# Altium schematic sheet ESP32-WROVER-Camera-V1.0.SchDoc
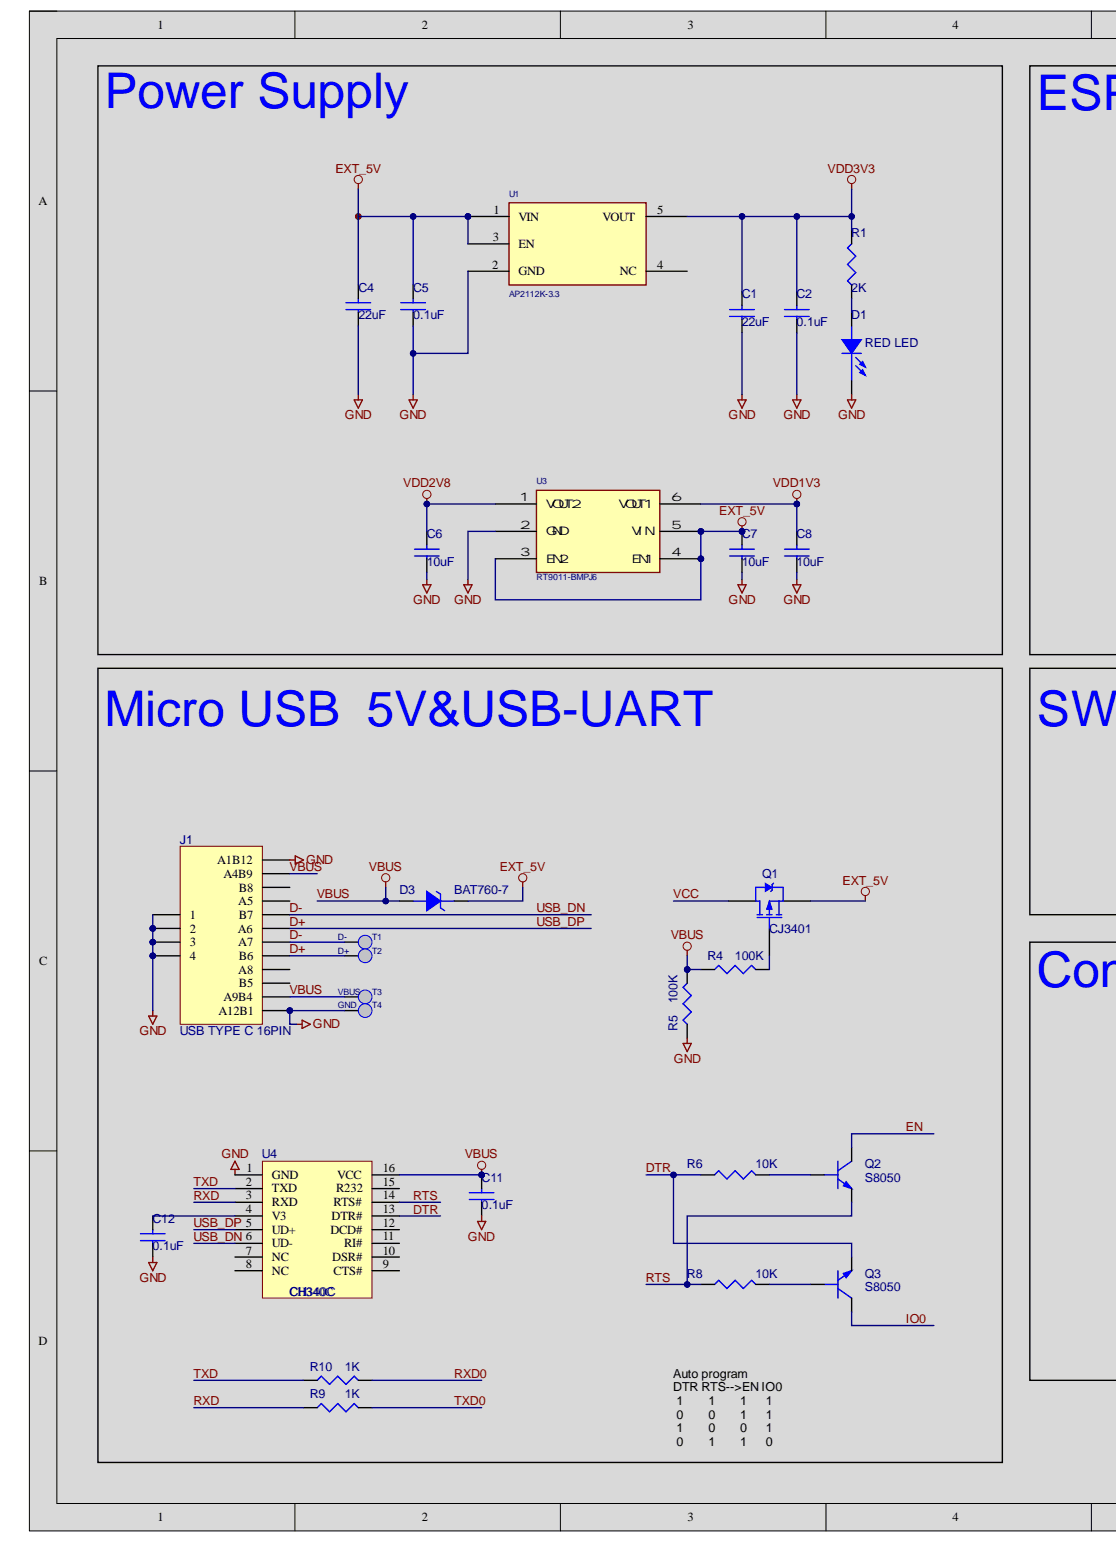
[diagram p0: ESP32-WROVER-Camera-V1.0.SchDoc, left]
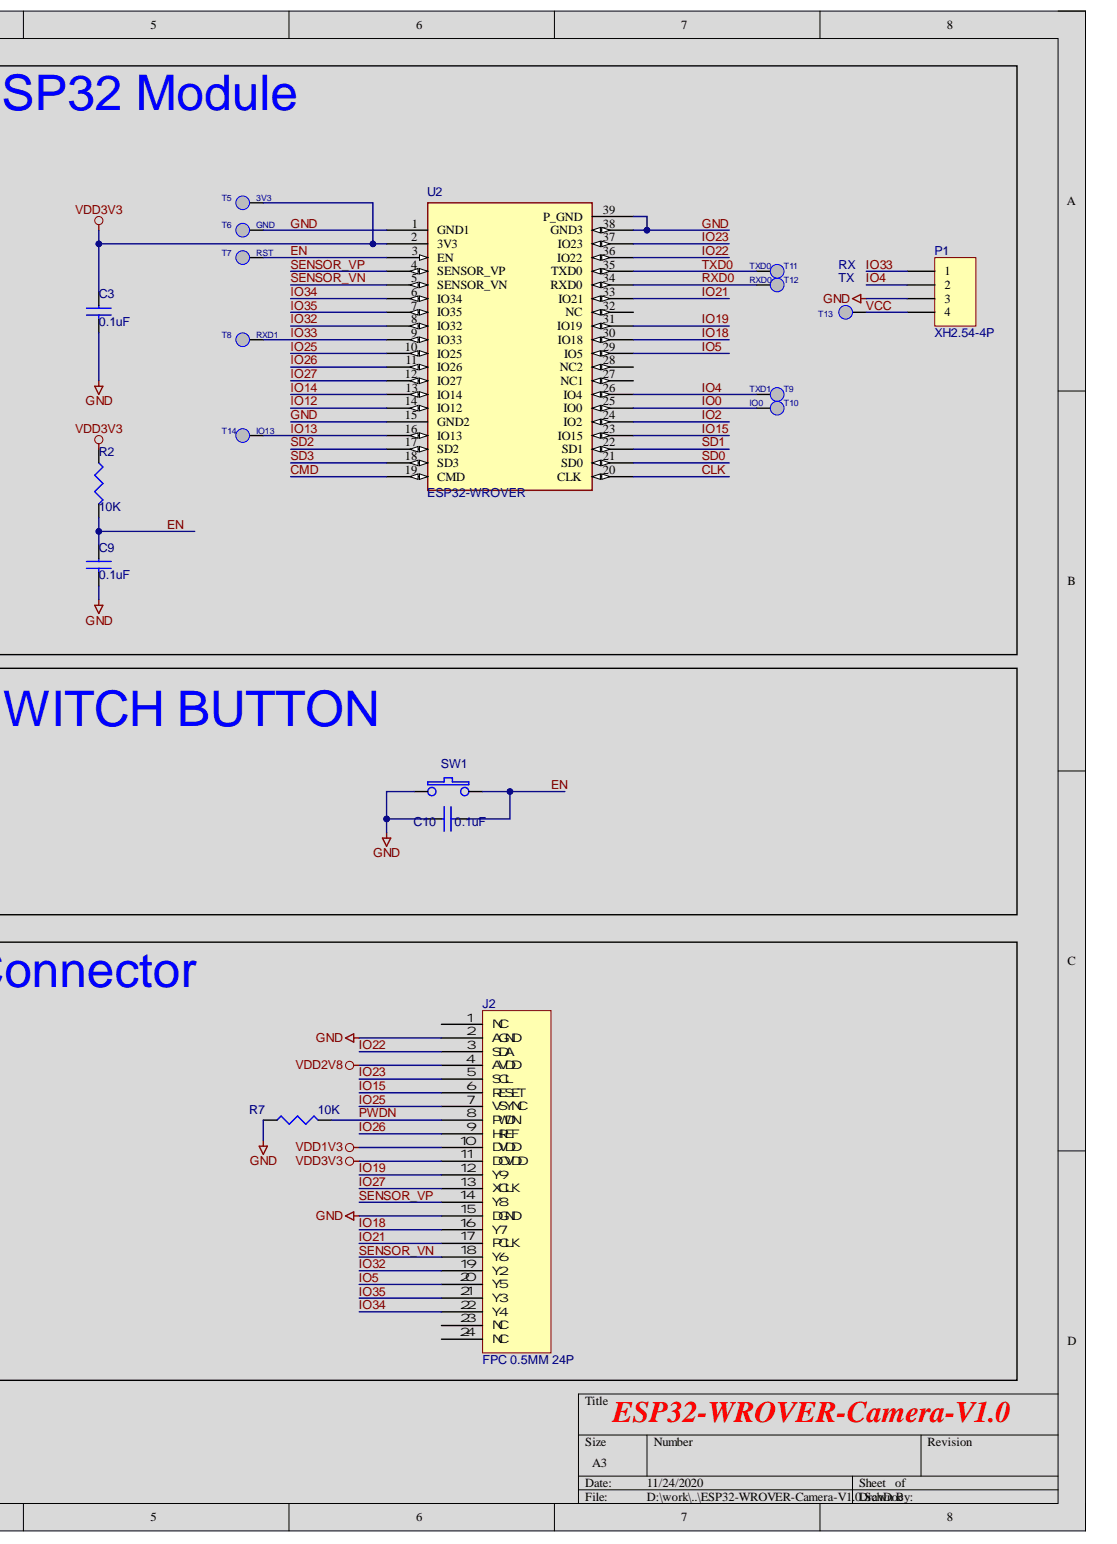
[diagram p1: ESP32-WROVER-Camera-V1.0.SchDoc, right]

## Parts
C1  22uF  [C0603]
C2  0.1uF  [C0603]
C3  0.1uF  [C0603]
C4  22uF  [C0603]
C5  0.1uF  [C0603]
C6  10uF  [C0603]
C7  10uF  [C0603]
C8  10uF  [C0603]
C9  0.1uF  [C0603]
C10  0.1uF  [C0603]
C11  0.1uF  [C0603]
C12  0.1uF  [C0603]
D1  RED LED  [led0603]
D3  BAT760-7  [SOD323]
J1  USB TYPE C 16PIN  [USB-Type-C-16]
J2  FPC 0.5MM 24P  [FPC 0.5MM 24P]
P1  XH2.54-4P  [XH2.54-4AWB]
Q1  CJ3401  [SOT-23-3]
Q2  S8050  [SOT-23-3]
Q3  S8050  [SOT-23-3]
R1  2K  [r0603]
R2  10K  [r0603]
R4  100K  [r0603]
R5  100K  [r0603]
R6  10K  [r0603]
R7  10K  [r0603]
R8  10K  [r0603]
R9  1K  [r0603]
R10  1K  [r0603]
SW1  SW PUSHBUTTON  [SW_3X4X2MM]  (value not drawn)
T1  D-  [PAD1.2mm]
T2  D+  [PAD1.2mm]
T3  VBUS  [PAD1.2mm]
T4  GND  [PAD1.2mm]
T5  3V3  [PAD1.2mm]
T6  GND  [PAD1.2mm]
T7  RST  [PAD1.2mm]
T8  RXD1  [PAD1.2mm]
T9  TXD1  [PAD1.2mm]
T10  IO0  [PAD1.2mm]
T11  TXD0  [PAD1.2mm]
T12  RXD0  [PAD1.2mm]
T13  VCC  [PAD1.2mm]
T14  IO13  [PAD1.2mm]
U1  AP2112K-3.3  [SOT23-5]
U2  ESP32-WROVER  [ESP32_MODULE_2COL]
U3  RT9011-BMPJ6  [SOT23-6]
U4  CH340C  [sop-16]

## Nets
EXT_5V: C4.2 C5.2 C7.2 D3.1 Q1.2 U1.1(VIN) U1.3(EN) U3.3(EN2) U3.4(EN1) U3.5(VIN)
VDD1V3: C8.2 J2.10(DVDD) U3.6(VOUT1)
VDD2V8: C6.2 J2.4(AVDD) U3.1(VOUT2)
VDD3V3: C1.2 C2.2 C3.1 J2.11(DOVDD) R1.1 R2.1 T5.1 U1.5(VOUT) U2.2(3V3)
CLK: U2.20(CLK)
CMD: U2.19(CMD)
D+: J1.A6 J1.B6 T2.1 U4.5(UD+)
D-: J1.A7 J1.B7 T1.1 U4.6(UD-)
DTR: Q3.2 R6.1 U4.13(DTR#)
EN: C9.1 C10.2 Q2.3 R2.2 SW1.2 T7.1 U2.3(EN)
GND: C1.1 C2.1 C3.2 C4.1 C5.1 C6.1 C7.1 C8.1 C9.2 C10.1 C11.1 C12.1 D1.2 J1.1 J1.2 J1.3 J1.4 J1.A1B12 J1.A12B1 J2.2(AGND) J2.15(DGND) P1.3 R5.1 R7.1 SW1.1 T4.1 T6.1 U1.2(GND) U2.1(GND1) U2.15(GND2) U2.38(GND3) U2.39(P_GND) U3.2(GND) U4.1(GND)
IO0: Q3.3 T10.1 U2.25(IO0)
IO2: U2.24(IO2)
IO4: P1.2 T9.1 U2.26(IO4)
IO5: J2.20(Y5) U2.29(IO5)
IO12: U2.14(IO12)
IO13: T14.1 U2.16(IO13)
IO14: U2.13(IO14)
IO15: J2.6(RESET) U2.23(IO15)
IO18: J2.16(Y7) U2.30(IO18)
IO19: J2.12(Y9) U2.31(IO19)
IO21: J2.17(PCLK) U2.33(IO21)
IO22: J2.3(SDA) U2.36(IO22)
IO23: J2.5(SCL) U2.37(IO23)
IO25: J2.7(VSYNC) U2.10(IO25)
IO26: J2.9(HREF) U2.11(IO26)
IO27: J2.13(XCLK) U2.12(IO27)
IO32: J2.19(Y2) U2.8(IO32)
IO33: P1.1 T8.1 U2.9(IO33)
IO34: J2.22(Y4) U2.6(IO34)
IO35: J2.21(Y3) U2.7(IO35)
PWDN: J2.8(PWDN) R7.2
RTS: Q2.2 R8.1 U4.14(RTS#)
RXD: R9.1 U4.3(RXD)
RXD0: R10.2 T12.1 U2.34(RXD0)
SD0: U2.21(SD0)
SD1: U2.22(SD1)
SD2: U2.17(SD2)
SD3: U2.18(SD3)
SENSOR_VN: J2.18(Y6) U2.5(SENSOR_VN)
SENSOR_VP: J2.14(Y8) U2.4(SENSOR_VP)
TXD: R10.1 U4.2(TXD)
TXD0: R9.2 T11.1 U2.35(TXD0)
VBUS: C11.2 D3.2 J1.A4B9 J1.A9B4 R4.1 R5.2 T3.1 U4.16(VCC)
VCC: P1.4 Q1.3 T13.1
NetC12_2: C12.2 U4.4(V3)
NetD1_1: D1.1 R1.2
NetQ1_1: Q1.1 R4.2
NetQ2_1: Q2.1 R6.2
NetQ3_1: Q3.1 R8.2

Not connected: J1 A5 A8 B5 B8; J2 1(NC) 23(NC) 24(NC); U1 4(NC); U2 27(NC1) 28(NC2) 32(NC); U4 7(NC) 8(NC) 9(CTS#) 10(DSR#) 11(RI#) 12(DCD#) 15(R232)

## Library data of the parts
C1-C12 = CAP NP: Component Kind: Standard
D1 = LED_1: Component Kind: Standard
D3 = DIODE_POWER_0: Component Kind: Standard
J1 = CON5: Connector; Component Kind: Standard; Library Name: ESP32-UNO-Kitc-V01.SCHLIB
J2 = FPC 0.5MM 24P: FPC 0.5MM 24P 翻盖式 H2.0mm 下接; Component Kind: Standard; Library Name: ESP32-Camera-V01.SCHLIB
P1 = Header 4: Header, 4-Pin; Component Kind: Standard; LatestRevisionDate: 17-Jul-2002; LatestRevisionNote: Re-released for DXP Platform.; Library Name: Miscellaneous Connectors.IntLib; Signal Integrity: Connector; Publisher: Altium Limited
Q1 = PMOS-2: P-Channel Power MOSFET; Note: Pin 4 not drawn and electrically connected to Pin 2.; Component Kind: Standard; ComponentLink1Description: Manufacturer Link; ComponentLink1URL: http://www.irf.com/; DatasheetVersion: PD-9.390D; LatestRevisionDate: 17-Jul-2002; LatestRevisionNote: Re-released for DXP Platform.; Library Name: Miscellaneous Devices.IntLib; PackageDescription: TO, Thru-Hole, Vertical, Heatsink Mounted; 3 In-Line Leads; Pitch 2.54 mm; PackageReference: TO-220AB; PackageVersion: Feb-1997; Published: 8-Jun-2000; Simulation: IRF9510; Publisher: Altium Limited
Q2 Q3 = NPN BCE_0: PspiceTemplate: Q^@REFDES %C %B %E; Component Kind: Standard; Simulation: NPN BCE_0
R1 R2 = RESISTOR_0: Component Kind: Standard
R4-R8 = RESISTOR_0: SIGNAL_MODEL: DEFAULT_RESISTOR_200OHM_1_2; Component Kind: Standard
R9 R10 = RESISTOR_0: SIGNAL_MODEL: DEFAULT_RESISTOR_10000OHM_1_2; Component Kind: Standard
T1-T14 = TEST: Component Kind: Standard; Description: TEST; Library Name: ESP32-WROVER-Camera-V02.SCHLIB
U1 = AP2112K: Component Kind: Standard; Description: AP2112K-3.3; Library Name: ESP32-Camera-V01.SCHLIB
U2 = ESP32_MODULE_3: Component Kind: Standard
U3 = RT9011-MGGJ6: Component Kind: Standard; Description: RT9011-MGGJ6; Library Name: ESP32-Camera-V01.SCHLIB
SW1 = SW PUSHBUTTON: Component Kind: Standard
U4 = CH340C: Component Kind: Standard; Library Name: Schlib.SchLib
